# Altium schematic sheet DCDC_LIN_CONN.SchDoc(DCDC_LIN_CONN)
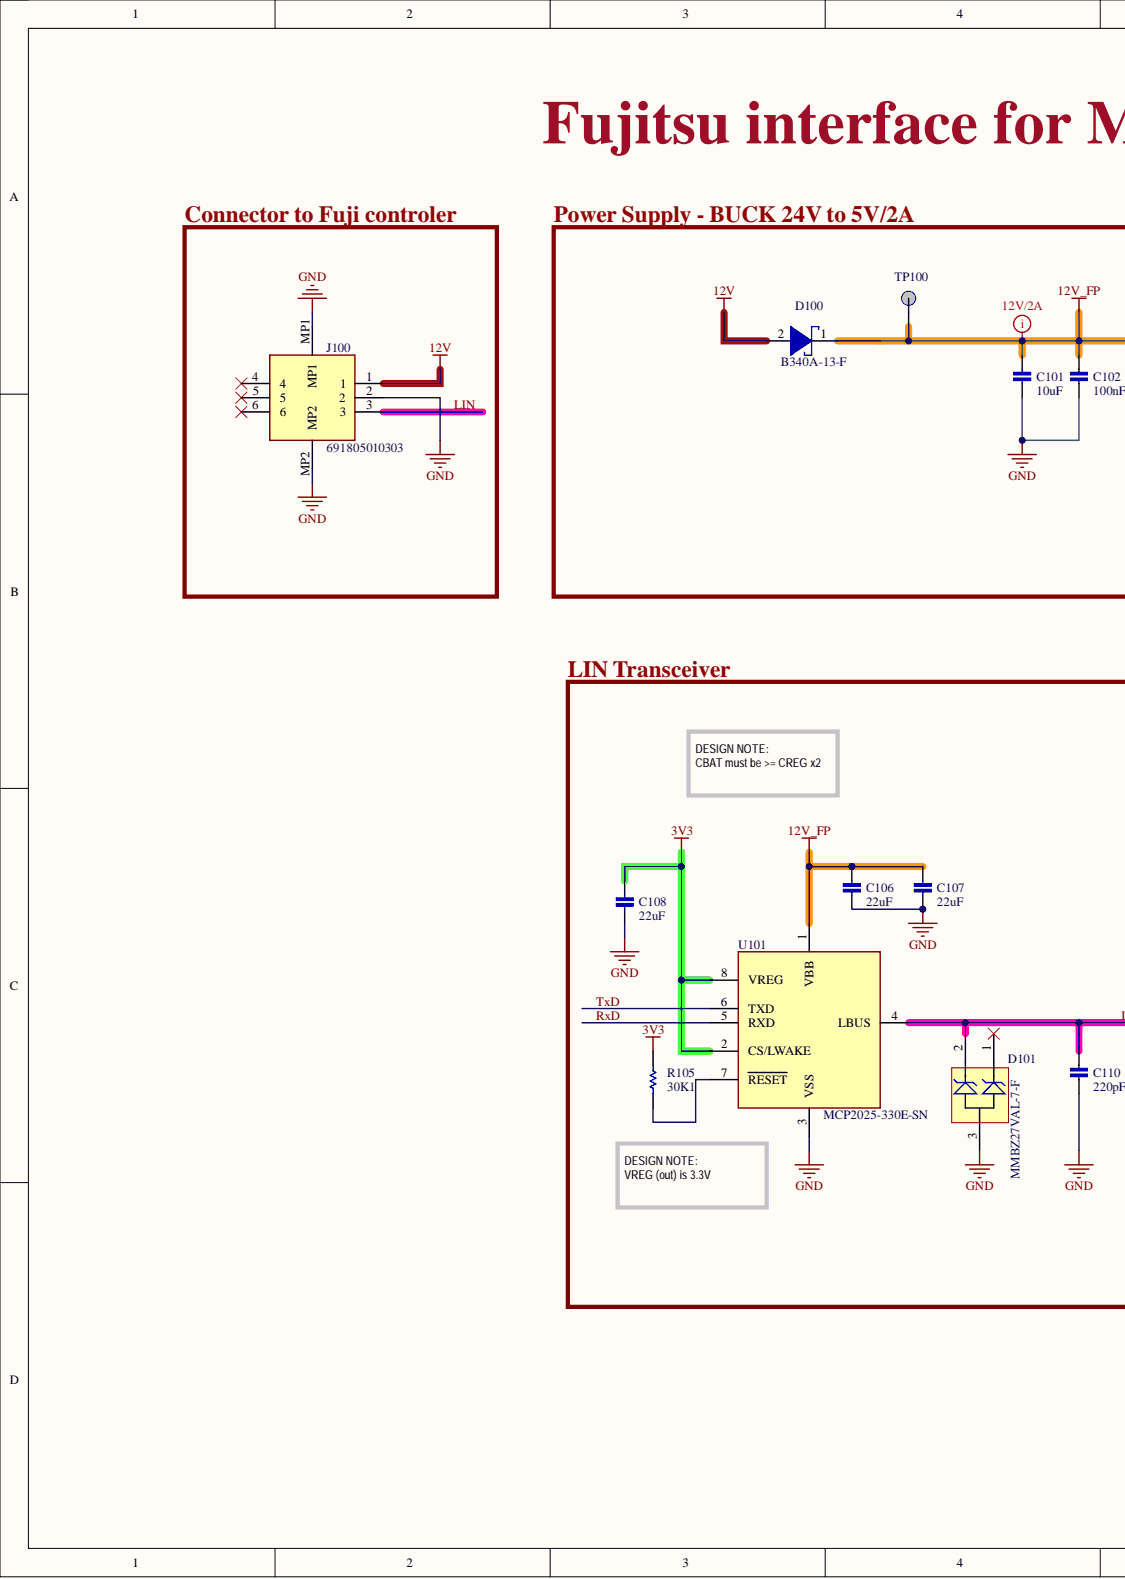
[diagram p0: DCDC_LIN_CONN.SchDoc, left]
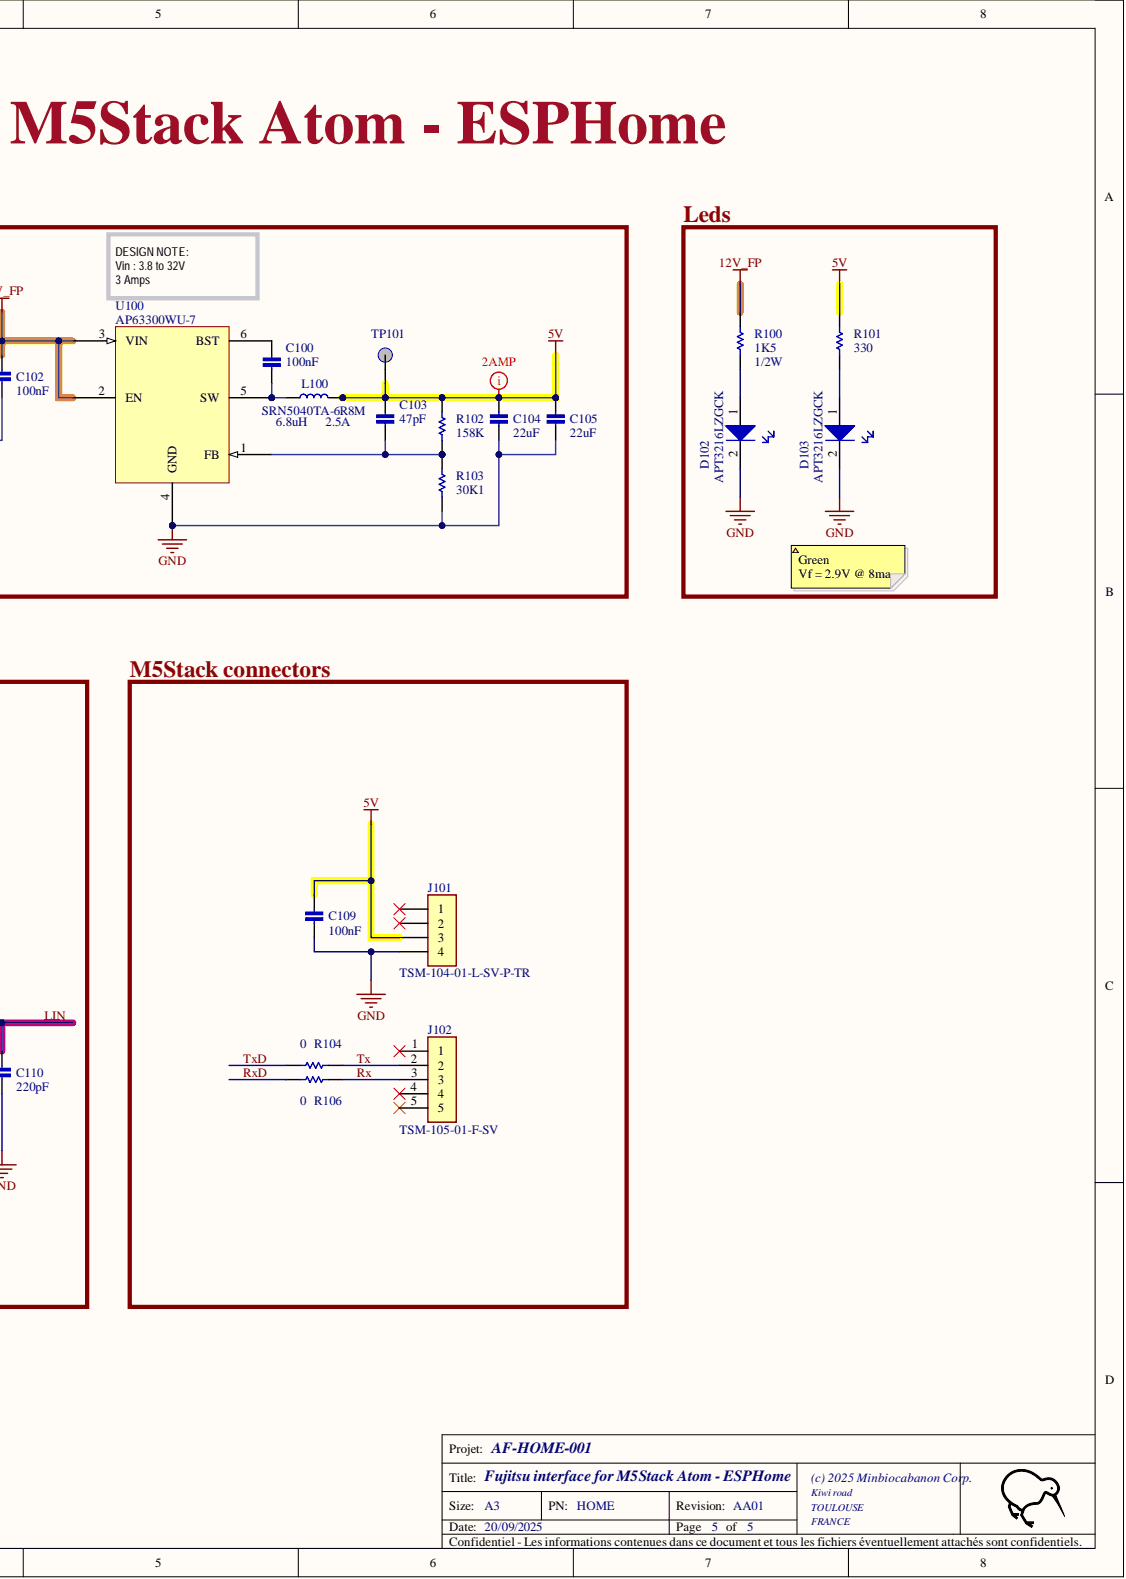
[diagram p1: DCDC_LIN_CONN.SchDoc, right]

## Parts
C100  100nF  [0603_CAPA]
C101  10uF  [1206_CAPA]
C102  100nF  [0603_CAPA]
C103  47pF  [0603_CAPA]
C104  22uF  [0805_CAPA]
C105  22uF  [0805_CAPA]
C106  22uF  [0805_CAPA]
C107  22uF  [0805_CAPA]
C108  22uF  [0805_CAPA]
C109  100nF  [0603_CAPA]
C110  220pF  [0603_CAPA]
D100  B340A-13-F  [DIODE_B340A-13-F]
D101  MMBZ27VAL-7-F  [ESD_MMBZ27VAL-7-F]
D102  APT3216LZGCK  [LED_APT3216LZGCK]
D103  APT3216LZGCK  [LED_APT3216LZGCK]
J100  691805010303  [691805010303]
J101  TSM-104-01-L-SV-P-TR  [TSM-104-01-L-SV-P-TR]
J102  TSM-105-01-F-SV  [TSM-105-01-F-SV]
L100  SRN5040TA-6R8M  Current Rating: 2.5A  [INDUCTOR_652-SRN5040TA-100M]
R100  1K5  [0805_RES]
R101  330  [0603_RES]
R102  158K  [0603_RES]
R103  30K1  [0603_RES]
R104  0  [0603_RES]
R105  30K1  [0603_RES]
R106  0  [0603_RES]
TP100  5V_BUCK  [PTEST]  (value not drawn)  (no BOM)
TP101  5V_BUCK  [PTEST]  (value not drawn)  (no BOM)
U100  AP63300WU-7  [POWER_BUCK_AP63300WU-7]
U101  MCP2025-330E-SN  [MCP2025-330E-SN]

## Nets
3V3: C108.1 R105.2 U101.2(CS/LWAKE) U101.8(VREG)
5V: C103.1 C104.1 C105.1 C109.1 J101.3 L100.2 R101.2 R102.2 TP101.1
12V: D100.2 J100.1
12V_FP: C101.1 C102.1 C106.1 C107.1 D100.1 R100.2 TP100.1 U100.2(EN) U100.3(VIN) U101.1(VBB)
GND: C101.2 C102.2 C104.2 C105.2 C106.2 C107.2 C108.2 C109.2 C110.2 D101.3 D102.2 D103.2 J100.2 J100.MP1 J100.MP2 J101.4 R103.1 U100.4(GND) U101.3(VSS)
LIN: C110.1 D101.2 J100.3 U101.4(LBUS)
Rx: J102.3 R106.2
RxD: R106.1 U101.5(RXD)
Tx: J102.2 R104.2
TxD: R104.1 U101.6(TXD)
NetC100_1: C100.1 U100.6(BST)
NetC100_2: C100.2 L100.1 U100.5(SW)
NetC103_2: C103.2 R102.1 R103.2 U100.1(FB)
NetD102_1: D102.1 R100.1
NetD103_1: D103.1 R101.1
NetR105_1: R105.1 U101.7(RESET)

Not connected: D101 1; J100 4 5 6; J101 1 2; J102 1 4 5

## Library data of the parts
C100 C102 C109 = CAPA_CMS_100nF_50V_0603_5%_X7R: 100nF_50V_0603_5%_X7R; Database Table Name: Capacitors; Tolerance: 5%; Component Kind: Standard; Manufacturer Part Number: CAPA_CMS_100nF_50V_0603_5%_X7R; Mounting Type: CMS; Library Name: OILibrary.DbLib
C101 = CAPA_CMS_10uF_50V_1206_10%_X7R: 10uF_50V_1206_10%_X7R; Database Table Name: Capacitors; Tolerance: 10%; Component Kind: Standard; Manufacturer Part Number: CAPA_CMS_10uF_50V_1206_10%_X7R; Mounting Type: CMS; Library Name: OILibrary.DbLib
C103 = CAPA_CMS_47pF_50V_0603_5%_X7R: 47pF_50V_0603_5%_X7R; Database Table Name: Capacitors; Tolerance: 5%; Component Kind: Standard; Manufacturer Part Number: CAPA_CMS_47pF_50V_0603_5%_X7R; Mounting Type: CMS; Library Name: OILibrary.DbLib
C104-C108 = CAPA_CMS_22uF_16V_0805_10%_X5R: 22uF_16V_0805_10%_X5R; Database Table Name: Capacitors; Tolerance: 10%; Component Kind: Standard; Manufacturer Part Number: CAPA_CMS_22uF_16V_0805_10%_X5R; Mounting Type: CMS; Library Name: OILibrary.DbLib
C110 = CAPA_CMS_220pF_50V_0603_10%_X7R: 220pF_50V_0603_10%_X7R; Database Table Name: Capacitors; Tolerance: 10%; Component Kind: Standard; Manufacturer Part Number: CAPA_CMS_220pF_50V_0603_10%_X7R; Mounting Type: CMS; Library Name: OILibrary.DbLib
D100 = B340A-13-F: Diodes et redresseurs Schottky 40V 3A; Life Status: Active; Component Kind: Standard; ComponentLink1Description: https://www.mouser.fr/datasheet/2/115/ds30891-89887.pdf; Current Rating: 3A; Database Table Name: Diodes; Diode Type: Schottky; Manufacturer: Diodes; Manufacturer Part Number: B340A-13-F; Mounting Type: CMS; Voltage Rating: 40V; Library Name: OILibrary.DbLib
D101 = MMBZ27VAL-7-F: Suppresseurs ESD / diodes TVS 27V 225mW; Life Status: Active; Component Kind: Standard; ComponentLink1Description: https://www.mouser.fr/datasheet/2/115/DIOD_S_A0012799209_1-2543783.pdf; Database Table Name: Diodes; Diode Type: TVS; Manufacturer Part Number: MMBZ27VAL-7-F; Mounting Type: CMS; Voltage Rating: 27V; Library Name: OILibrary.DbLib
D102 D103 = APT3216LZGCK: LED CMS Green 3.2x1.6mm; Manufacturer: Kingbright; Database Table Name: LEDs; Life Status: Active; Light Intensity: 100mcd; Nominal Current: 20mA; Color: Green; Component Kind: Standard; ComponentLink1Description: https://www.kingbrightusa.com/images/catalog/SPEC/APT3216LZGCK.pdf; Manufacturer Part Number: APT3216LZGCK; Mounting Type: CMS; Supplier 1: Mouser; Supplier Part Number 1: 604-APT3216LZGCK; Library Name: OILibrary.DbLib
J100 = 691805010303: WR-TBL Series 8050 - 3 pins 2.50 mm Screwless SMT; Manufacturer: Wurth Elektronik; Connector Type: BORNIER; Database Table Name: Connectors; Life Status: Active; Component Kind: Standard; ComponentLink1Description: https://www.we-online.com/components/products/datasheet/691805010303.pdf; Manufacturer Part Number: 691805010303; Mounting Type: CMS; Supplier 1: Mouser; Supplier Part Number 1: 710-691805010303; Library Name: OILibrary.DbLib
J101 = TSM-104-01-L-SV-P-TR: Header male SMD 1x4; Manufacturer: Samtec; Database Table Name: Connectors; Life Status: Active; Component Kind: Standard; ComponentLink1Description: https://suddendocs.samtec.com/catalog_english/tsm.pdf; Connector Type: HEADER; Manufacturer Part Number: TSM-104-01-L-SV-P-TR; Mounting Type: CMS; Library Name: OILibrary.DbLib
J102 = TSM-105-01-F-SV: Header male SMD 1x5; Manufacturer: SAMTEC; Database Table Name: Connectors; Life Status: Active; Component Kind: Standard; ComponentLink1Description: https://suddendocs.samtec.com/catalog_english/tsm.pdf; Connector Type: HEADER; Manufacturer Part Number: TSM-105-01-F-SV; Mounting Type: CMS; Supplier 1: Mouser; Supplier Part Number 1: 200-TSM10501FSV; Library Name: OILibrary.DbLib
L100 = SRN5040TA-6R8M: Inductance fixes 6.8uH 2.5A; Manufacturer: Bourns; Database Table Name: Inductors; Life Status: Active; Component Kind: Standard; ComponentLink1Description: https://www.mouser.fr/ProductDetail/Bourns/SRN5040TA-6R8M?qs=qSfuJ%252Bfl%2Fd6O0PuOfrvvvw%3D%3D; Inductance: 6.8uH; Manufacturer Part Number: SRN5040TA-6R8M; Mounting Type: CMS; Library Name: OILibrary.DbLib
R100 = RES_CMS_1K5_1/8W_0805_0.1%: 1K5_1/8W_0805_0.1%; Database Table Name: Resistors; Tolerance: 0.1%; Component Kind: Standard; Manufacturer Part Number: RES_CMS_1K5_1/8W_0805_0.1%; Mounting Type: CMS; Library Name: OILibrary.DbLib
R101 = RES_CMS_330_1/10W_0603_5%: 330_1/10W_0603_5%; Database Table Name: Resistors; Tolerance: 5%; Component Kind: Standard; Manufacturer Part Number: RES_CMS_330_1/10W_0603_5%; Mounting Type: CMS; Library Name: OILibrary.DbLib
R102 = RES_CMS_158K_1/16W_0603_1%: 158K_1/16W_0603_1%; Database Table Name: Resistors; Tolerance: 1%; Component Kind: Standard; Manufacturer Part Number: RES_CMS_158K_1/16W_0603_1%; Mounting Type: CMS; Library Name: OILibrary.DbLib
R103 R105 = RES_CMS_30K1_1/10W_0603_1%: 30K1_1/10W_0603_1%; Database Table Name: Resistors; Tolerance: 1%; Component Kind: Standard; Manufacturer Part Number: RES_CMS_30K1_1/10W_0603_1%; Mounting Type: CMS; Library Name: OILibrary.DbLib
R104 R106 = RES_CMS_0_1/10W_0603_1%: 0_1/10W_0603_1%; Database Table Name: Resistors; Tolerance: 1%; Component Kind: Standard; Manufacturer Part Number: RES_CMS_0_1/10W_0603_1%; Mounting Type: CMS; Library Name: OILibrary.DbLib
TP100 TP101 = PTEST: Test point; Manufacturer: OPENINDUS; Database Table Name: Catalog; Component Type: TEST_POINT; Library Name: OILibrary.DbLib; Manufacturer Part Number: PTEST; Mounting Type: NONE
U100 = AP63300WU-7: Régulateurs de tension de commutation DCDC Conv HV Buck TSOT26 T&R 3K; Manufacturer: Diodes Incorporated; Database Table Name: Catalog; Component Kind: Standard; Component Type: POWER; ComponentLink1Description: https://www.mouser.fr/ProductDetail/Diodes-Incorporated/AP63300WU-7?qs=%2Fha2pyFadugaSvYQ6ijkxuBwxLy95hAk9rc3GTfKFIE%3D; Manufacturer Part Number: AP63300WU-7; Mounting Type: CMS; Library Name: OILibrary.DbLib
U101 = MCP2025-330E/SN: LIN Transceiver with Voltage Regulator SOIC 3.3V; Manufacturer: Microchip; Database Table Name: Catalog; Life Status: Active; Component Kind: Standard; Component Type: TRANSCEIVER; ComponentLink1Description: https://ww1.microchip.com/downloads/en/DeviceDoc/20002306B.pdf; Library Name: OILibrary.DbLib; Manufacturer Part Number: MCP2025-330E/SN; Mounting Type: CMS; Supplier 1: Mouser; Supplier Part Number 1: 579-MCP2025-330E/SN
